# Altium schematic sheet [5]-MCU_POWER.SchDoc([5]-MCU_POWER)
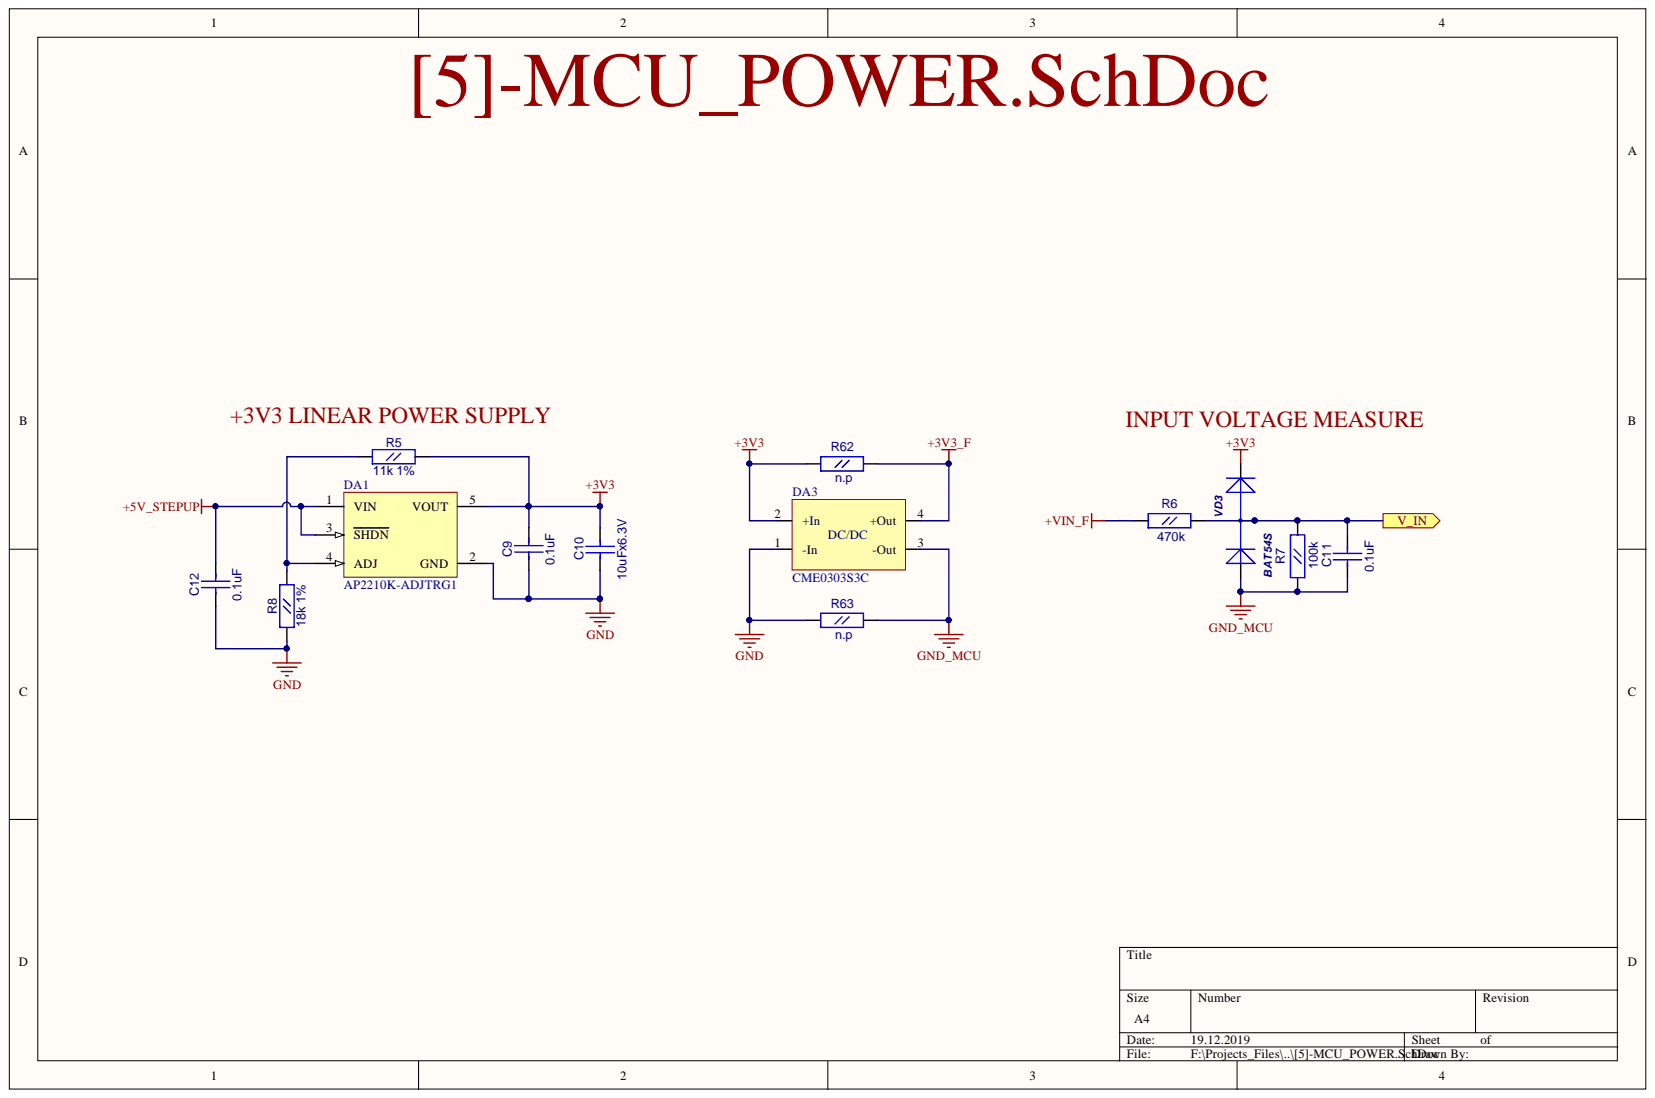
[diagram p0: [5]-MCU_POWER.SchDoc]

## Parts
C9  0.1uF  [CC0603]
C10  10uFx6.3V  [CC0805]
C11  0.1uF  [CC0603]
C12  0.1uF  [CC0603]
DA1  AP2210K-ADJTRG1  [SOT23-5]
DA3  CME0303S3C  [CME0303S3C]
R5  11k 1%  [RC0603]
R6  470k  [RC0603]
R7  100k  [RC0603]
R8  18k 1%  [RC0603]
R62  n.p  [RC0805]
R63  n.p  [RC0805]
VD3  BAT54S  [SOT-23(GSD)]

## Ports
V_IN

## Nets
+3V3: C9.1 C10.2 DA1.5(VOUT) DA3.2(+In) R5.2 R62.1 VD3.2
+3V3_F: DA3.4(+Out) R62.2
+5V_STEPUP: C12.1 DA1.1(VIN) DA1.3(SHDN)
+VIN_F: R6.1
GND: C9.2 C10.1 C12.2 DA1.2(GND) DA3.1(-In) R8.1 R63.1
GND_MCU: C11.1 DA3.3(-Out) R7.1 R63.2 VD3.1
V_IN: C11.2 R6.2 R7.2 VD3.3 ; port V_IN
NetDA1_4: DA1.4(ADJ) R5.1 R8.2

## Library data of the parts
C9 C11 C12 = CC0603: Value: 0.1uF; Description: CC0603; Component Kind: Standard; Library Name: gprs_modem_2.SchLib
C10 = CC0805: Value: 10uFx6.3V; Description: CC0805; Component Kind: Standard; Library Name: gprs_modem_2.SchLib
DA1 = MCP1824T-ADJE/OT: 300 mA, Low Voltage, Low Quiescent Current LDO Regulator, 5-Pin SOT-223, Extended Temperature, Tape and Reel; Published: 29-Jan-2009; PackageVersion: revAW, Oct-2008; PackageReference: SOT-223-DC5; PackageDescription: 5-Lead Plastic Small Outline Transistor (DC) [SOT-223]; DatasheetVersion: revA, Aug-2007; ComponentLink3URL: http://ww1.microchip.com/downloads/en/PackagingSpec/00049AW.pdf; ComponentLink3Description: Packaging Specification; ComponentLink2URL: http://ww1.microchip.com/downloads/en/DeviceDoc/22070a.pdf; ComponentLink2Description: Datasheet; ComponentLink1URL: http://www.microchip.com; ComponentLink1Description: Manufacturer Link; Component Kind: Standard; Publisher: Altium Limited; Library Name: gprs_modem_2.SchLib
DA3 = CME0303S3C: DC/DC converter; Component Kind: Standard; Library Name: fizik_lib.SchLib
R5-R8 = RC0603: Description: RC0603; Component Kind: Standard
  R5: Value: 11k 1%
  R6: Value: 470k; Library Name: fizik_lib.SchLib
  R7: Value: 100k
  R8: Value: 18k 1%
  R5 R7 R8: Library Name: gprs_modem_2.SchLib
R62 R63 = RC0805: Value: n.p; Description: RC0805; Component Kind: Standard; Library Name: fizik_lib.SchLib
VD3 = BAT54S: 1.0 Ampere General Purpose Rectifier; ComponentField6: Published 8-Jun-2000, Modified 11-July-2000: SIM Model Added.; Simulation: DIODE; Component Kind: Standard; Library Name: gprs_modem_2.SchLib
